# Altium schematic sheet STM32L452RC.SchDoc
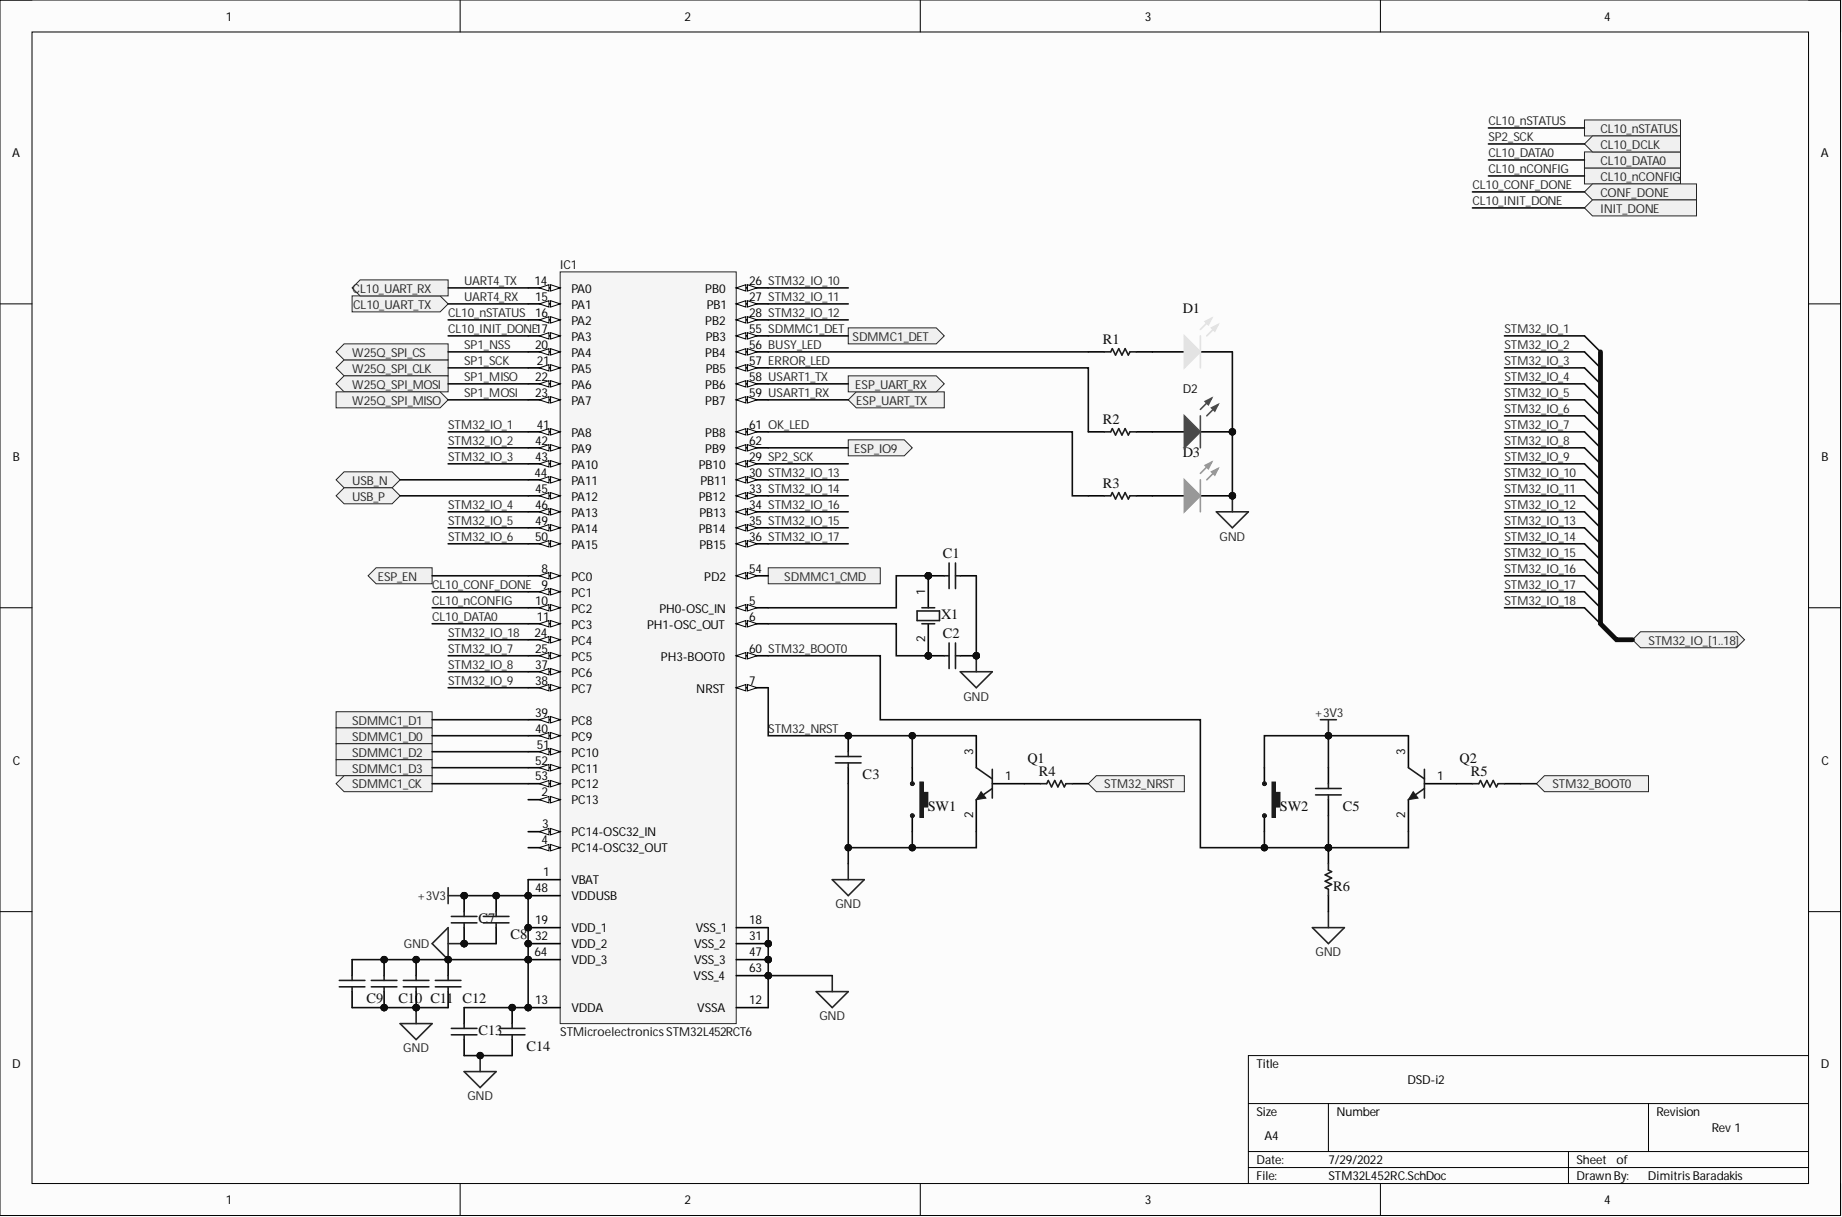
[diagram p0: STM32L452RC.SchDoc]

## Parts
C1  Murata GRM0335C1E6R0BA01D  [CAPC0603X33X15NL03T05]  (value not drawn)
C2  Murata GRM0335C1E6R0BA01D  [CAPC0603X33X15NL03T05]  (value not drawn)
C3  Taiyo Yuden LMK063BJ104KP-F  [PCB-0002-00713-1]  (value not drawn)
C5  Taiyo Yuden LMK063BJ104KP-F  [PCB-0002-00713-1]  (value not drawn)
C7  Samsung CL03A105MO3NRNH  [Samsung CL03A105MO3NRNH-Footprint-1]  (value not drawn)
C8  Taiyo Yuden LMK063BJ104KP-F  [PCB-0002-00713-1]  (value not drawn)
C9  Samsung CL05A475MP5NRNC  [PCB-ly623x0ckhe065kxsmmc-1]  (value not drawn)
C10  Taiyo Yuden LMK063BJ104KP-F  [PCB-0002-00713-1]  (value not drawn)
C11  Taiyo Yuden LMK063BJ104KP-F  [PCB-0002-00713-1]  (value not drawn)
C12  Taiyo Yuden LMK063BJ104KP-F  [PCB-0002-00713-1]  (value not drawn)
C13  Samsung CL03A105MO3NRNH  [Samsung CL03A105MO3NRNH-Footprint-1]  (value not drawn)
C14  Taiyo Yuden LMK063BJ104KP-F  [PCB-0002-00713-1]  (value not drawn)
D1  Würth Elektronik 150060YS75000  [PCB-rxfjlzgnb1funqct5a9u-1]  (value not drawn)
D2  Würth Elektronik 150060SS75000  [PCB-5fu3j7c0qqekthrt71i6-1]  (value not drawn)
D3  Würth Elektronik 150060VS75000  [PCB-6c9d90wl8nak4m67x5qd-1]  (value not drawn)
IC1  STMicroelectronics STM32L452RCT6  Manufacturer Part Number: STM32L452RCT6  [STMicroelectronics STM32L452RCT6-Footprint-1]
Q1  BC848BLT3G  [ONSC-SOT-23-3-318-08_V]  (value not drawn)
Q2  BC848BLT3G  [ONSC-SOT-23-3-318-08_V]  (value not drawn)
R1  Panasonic ERJ-1GNF1620C  [Panasonic ERJ-1GNF1620C-Footprint-1]  (value not drawn)
R2  Panasonic ERJ-1GNF1620C  [Panasonic ERJ-1GNF1620C-Footprint-1]  (value not drawn)
R3  Panasonic ERJ-1GNF1620C  [Panasonic ERJ-1GNF1620C-Footprint-1]  (value not drawn)
R4  Bourns CR0201AJW-103GLF  [Bourns CR0201AJW-103GLF-Footprint-1]  (value not drawn)
R5  Bourns CR0201AJW-103GLF  [Bourns CR0201AJW-103GLF-Footprint-1]  (value not drawn)
R6  Bourns CR0201AJW-103GLF  [Bourns CR0201AJW-103GLF-Footprint-1]  (value not drawn)
SW1  Panasonic EVP-BB2A9B000  [PCB-nuaqfyekbkeoejgoofz7-1]  (value not drawn)
SW2  Panasonic EVP-BB2A9B000  [PCB-nuaqfyekbkeoejgoofz7-1]  (value not drawn)
X1  NDK NX3225GD-8MHZ-STD-CRA-3  [XTAL_2SM_3R2X2R5_A]  (value not drawn)

## Ports
CL10_DATA0, CL10_DCLK, CL10_nCONFIG, CL10_nSTATUS, CL10_UART_RX, CL10_UART_TX, CONF_DONE, ESP_EN, ESP_IO9, ESP_UART_RX, ESP_UART_TX, INIT_DONE, SDMMC1_CK, SDMMC1_CMD, SDMMC1_D0, SDMMC1_D1, SDMMC1_D2, SDMMC1_D3, SDMMC1_DET, STM32_BOOT0, STM32_IO_1, STM32_IO_2, STM32_IO_3, STM32_IO_4, STM32_IO_5, STM32_IO_6, STM32_IO_7, STM32_IO_8, STM32_IO_9, STM32_IO_10, STM32_IO_11, STM32_IO_12, STM32_IO_13, STM32_IO_14, STM32_IO_15, STM32_IO_16, STM32_IO_17, STM32_IO_18, STM32_IO_[1..18], STM32_NRST, USB_N, USB_P, W25Q_SPI_CLK, W25Q_SPI_CS, W25Q_SPI_MISO, W25Q_SPI_MOSI

## Nets
+3V3: C5.2 C7.2 C8.2 C9.2 C10.2 C11.2 C12.2 C13.2 C14.2 IC1.1(VBAT) IC1.13(VDDA) IC1.19(VDD_1) IC1.32(VDD_2) IC1.48(VDDUSB) IC1.64(VDD_3) Q2.3 SW2.1
GND: C1.2 C2.2 C3.1 C7.1 C8.1 C9.1 C10.1 C11.1 C12.1 C13.1 C14.1 D1.2 D2.2 D3.2 IC1.12(VSSA) IC1.18(VSS_1) IC1.31(VSS_2) IC1.47(VSS_3) IC1.63(VSS_4) Q1.2 R6.1 SW1.2
BUSY_LED: IC1.56(PB4) R1.1
CL10_CONF_DONE: IC1.9(PC1) ; port CONF_DONE
CL10_DATA0: IC1.11(PC3) ; port CL10_DATA0
CL10_INIT_DONE: IC1.17(PA3) ; port INIT_DONE
CL10_nCONFIG: IC1.10(PC2) ; port CL10_nCONFIG
CL10_nSTATUS: IC1.16(PA2) ; port CL10_nSTATUS
ERROR_LED: IC1.57(PB5) R2.1
ESP_EN: IC1.8(PC0) ; port ESP_EN
ESP_IO9: IC1.62(PB9) ; port ESP_IO9
OK_LED: IC1.61(PB8) R3.1
SDMMC1_CK: IC1.53(PC12) ; port SDMMC1_CK
SDMMC1_CMD: IC1.54(PD2) ; port SDMMC1_CMD
SDMMC1_D0: IC1.40(PC9) ; port SDMMC1_D0
SDMMC1_D1: IC1.39(PC8) ; port SDMMC1_D1
SDMMC1_D2: IC1.51(PC10) ; port SDMMC1_D2
SDMMC1_D3: IC1.52(PC11) ; port SDMMC1_D3
SDMMC1_DET: IC1.55(PB3) ; port SDMMC1_DET
SP1_MISO: IC1.22(PA6) ; port W25Q_SPI_MOSI
SP1_MOSI: IC1.23(PA7) ; port W25Q_SPI_MISO
SP1_NSS: IC1.20(PA4) ; port W25Q_SPI_CS
SP1_SCK: IC1.21(PA5) ; port W25Q_SPI_CLK
SP2_SCK: IC1.29(PB10) ; port CL10_DCLK
STM32_BOOT0: C5.1 IC1.60(PH3-BOOT0) Q2.2 R6.2 SW2.2
STM32_IO_1: IC1.41(PA8) ; port STM32_IO_[1..18]  [also labelled ]
STM32_IO_2: IC1.42(PA9) ; port STM32_IO_[1..18]  [also labelled ]
STM32_IO_3: IC1.43(PA10) ; port STM32_IO_[1..18]  [also labelled ]
STM32_IO_4: IC1.46(PA13) ; port STM32_IO_[1..18]  [also labelled ]
STM32_IO_5: IC1.49(PA14) ; port STM32_IO_[1..18]  [also labelled ]
STM32_IO_6: IC1.50(PA15) ; port STM32_IO_[1..18]  [also labelled ]
STM32_IO_7: IC1.25(PC5) ; port STM32_IO_[1..18]  [also labelled ]
STM32_IO_8: IC1.37(PC6) ; port STM32_IO_[1..18]  [also labelled ]
STM32_IO_9: IC1.38(PC7) ; port STM32_IO_[1..18]  [also labelled ]
STM32_IO_10: IC1.26(PB0) ; port STM32_IO_[1..18]  [also labelled ]
STM32_IO_11: IC1.27(PB1) ; port STM32_IO_[1..18]  [also labelled ]
STM32_IO_12: IC1.28(PB2) ; port STM32_IO_[1..18]  [also labelled ]
STM32_IO_13: IC1.30(PB11) ; port STM32_IO_[1..18]  [also labelled ]
STM32_IO_14: IC1.33(PB12) ; port STM32_IO_[1..18]  [also labelled ]
STM32_IO_15: IC1.35(PB14) ; port STM32_IO_[1..18]  [also labelled ]
STM32_IO_16: IC1.34(PB13) ; port STM32_IO_[1..18]  [also labelled ]
STM32_IO_17: IC1.36(PB15) ; port STM32_IO_[1..18]  [also labelled ]
STM32_IO_18: IC1.24(PC4) ; port STM32_IO_[1..18]  [also labelled ]
STM32_NRST: C3.2 IC1.7(NRST) Q1.3 SW1.1
UART4_RX: IC1.15(PA1) ; port CL10_UART_TX
UART4_TX: IC1.14(PA0) ; port CL10_UART_RX
USART1_RX: IC1.59(PB7) ; port ESP_UART_TX
USART1_TX: IC1.58(PB6) ; port ESP_UART_RX
USB_N: IC1.44(PA11) ; port USB_N
USB_P: IC1.45(PA12) ; port USB_P
NetC1_1: C1.1 IC1.5(PH0-OSC_IN) X1.1
NetC2_1: C2.1 IC1.6(PH1-OSC_OUT) X1.2
NetD1_1: D1.1 R1.2
NetD2_1: D2.1 R2.2
NetD3_1: D3.1 R3.2
NetQ1_1: Q1.1 R4.1
NetQ2_1: Q2.1 R5.1
NetR4_2: R4.2 ; port STM32_NRST
NetR5_2: R5.2 ; port STM32_BOOT0

Not connected: IC1 2(PC13) 3(PC14-OSC32_IN) 4(PC14-OSC32_OUT)

## Library data of the parts
C1 C2 = CMP-3568632-2: Multilayer Ceramic Capacitors MLCC - SMD/SMT 0201 6.0pF 25volts C0G +/-0.1pF; Capacitance: 6e-12 F; Case/Package (SI): 0603; Dielectric Characteristic: C0G/NP0; Voltage Rating (DC): 25.0 V; Component Kind: Standard; Library Name: My Personal Space; Lifecycle Status: Active; Manufacturer Part Number: GRM0335C1E6R0BA01D; Octopart Url: https://octopart.com/grm0335c1e6r0ba01d-murata-24611420; OctopartID: cfb407c9608e1073; RoHS: Compliant; Size-Length: 0.0006 m; Size-Thickness: 0.0003 m; Size-Width: 0.0003 m
C3 C5 C8 C10-C12 C14 = CMP-e92820824df736ef-2: 0201 0.1 uF 10 V ±10% Tolerance X5R SMT Multilayer Ceramic Capacitor; Capacitance: 100 nF; Lead-Free Status: Lead Free; Voltage Rating (DC): 10.0 V; Capacitance Tolerance: ±10%; Case/Package: 0201; Component Kind: Standard; Dielectric Characteristic: X5R; Halogen Free Status: Halogen Free; Library Name: My Personal Space; Lifecycle Status: Active; Manufacturer Part Number: LMK063BJ104KP-F; Mounting Style: Surface Mount; Number of Pins: 2; Octopart URL: https://octopart.com/lmk063bj104kp-f-taiyo+yuden-10518969; OctopartID: e92820824df736ef; Operating Temperature: -55.0 °C to 85.0 °C; Packaging: Cut Tape (CT); RoHS: Compliant
C7 C13 = CMP-0a3ac1e7a1fcd5fd-1: CL Series 0201 1 uF 16 V ±20% Tolerance X5R Multilayer Ceramic Chip Capacitor; Lead-Free Status: Lead Free; Case/Package: 0201; Component Kind: Standard; Library Name: My Personal Space; Manufacturer Part Number: CL03A105MO3NRNH; Mounting Style: Surface Mount; Octopart Url: https://octopart.com/cl03a105mo3nrnh-samsung-47681184; OctopartID: 0a3ac1e7a1fcd5fd; Packaging: Tape & Reel (TR); RoHS: Compliant
C9 = CMP-45408d1ae5db9a87-4: Cap Ceramic 4.7uF 10V X5R 20% SMD 0402 85°C Paper T/R; Lead-Free Status: Lead Free; Component Kind: Standard; Library Name: My Personal Space; Manufacturer Part Number: CL05A475MP5NRNC; Octopart URL: https://octopart.com/cl05a475mp5nrnc-samsung-22054397; OctopartID: 45408d1ae5db9a87; RoHS: Compliant
D1 = CMP-12518863-10: Led, Yellow, 590 Nm, 2 V, 30 Ma, 120 Mcd Rohs Compliant: Yes; Forward Voltage: 2.00 V; Luminous Intensity: 120 mcd; Viewing Angle: 140 °; Case/Package: 0603; Color: Yellow; Component Kind: Standard; Library Name: My Personal Space; Manufacturer Part Number: 150060YS75000; Mounting Style: Surface Mount; Octopart URL: https://octopart.com/150060ys75000-w%C3%BCrth+elektronik-29687085; OctopartID: fe0f36c1264421c8; Packaging: Tape & Reel (TR); REACH SVHC Compliance: No SVHC; RoHS: Compliant
D2 = CMP-12518860-6: In a Pack of 100, 2.4 V Red LED 1608 (0603) SMD, Wurth Elektronik WL-SMCW 150060SS75000; Forward Voltage: 1.90 V; Luminous Intensity: 60.0 mcd; Viewing Angle: 140 °; Case/Package: 0603; Color: Red; Component Kind: Standard; Library Name: My Personal Space; Manufacturer Part Number: 150060SS75000; Mounting Style: Surface Mount; Octopart URL: https://octopart.com/150060ss75000-w%C3%BCrth+elektronik-29717777; OctopartID: 11d1d91e41a6ce16; Packaging: Reel; REACH SVHC Compliance: No SVHC; RoHS: Compliant
D3 = CMP-12518862-5: Led, Green, 570 Nm, 2 V, 30 Ma, 40 Mcd Rohs Compliant: Yes; Forward Voltage: 2.00 V; Luminous Intensity: 40.0 mcd; Viewing Angle: 140 °; Case/Package: 0603; Color: Green; Component Kind: Standard; Library Name: My Personal Space; Manufacturer Part Number: 150060VS75000; Mounting Style: Surface Mount; Octopart URL: https://octopart.com/150060vs75000-w%C3%BCrth+elektronik-29717778; OctopartID: 8ccb4404dcbf530c; Packaging: Cut Tape (CT); REACH SVHC Compliance: No SVHC; RoHS: Compliant
IC1 = CMP-dd4710ab05420280-1: MCU 32-Bit STM32L452xx ARM Cortex M4 RISC 256KB Flash 1.71V to 3.6V 64-Pin LQFP Tray; Clock Speed: 80.0 MHz; Number of Bits: 32; RAM Memory Size: 163840 B; Component Kind: Standard; Library Name: My Personal Space; Lifecycle Status: Not Listed by Manufacturer; Octopart Url: https://octopart.com/stm32l452rct6-stmicroelectronics-82247011; OctopartID: dd4710ab05420280; RoHS: Compliant
Q1 Q2 = CMP-860696-3: General Purpose Transistor, NPN Silicon, 3-Pin SOT-23, Pb-Free, Tape and Reel; Current Rating: 0.1 A; Lead-Free Status: Lead Free; Voltage Rating (DC): 30.0 V; Case/Package: SOT-23; Component Kind: Standard; Library Name: My Personal Space; Lifecycle Status: Active; Manufacturer Part Number: BC848BLT3G; Mounting Style: Surface Mount; Number of Pins: 3; Octopart Url: https://octopart.com/bc848blt3g-on+semiconductor-835077; OctopartID: 31b1f8b7aad2ee76; Packaging: Tape; Polarity: NPN; RoHS: Compliant
R1-R3 = CMP-3673637-4: Thick Film Resistors 0201 162ohm 1% Halogen Free; Power Rating: 0.05 W; Resistance: 162.0 Ω; Case/Package: 0201; Component Kind: Standard; Library Name: My Personal Space; Lifecycle Status: Not Listed by Manufacturer; Manufacturer Part Number: ERJ-1GNF1620C; Octopart Url: https://octopart.com/erj-1gnf1620c-panasonic-44402935; OctopartID: 2ca1e70e8130f366; Resistance Tolerance: ±1%; RoHS: Compliant
R4-R6 = CMP-0c15c370377fd3d2-2: Thick Film Chip Resistor; 0201 10k 5% 50mW TC200; Component Kind: Standard; Library Name: My Personal Space; Manufacturer Part Number: CR0201AJW-103GLF; Octopart Url: https://octopart.com/cr0201ajw-103glf-bourns-107945484; OctopartID: 0c15c370377fd3d2
SW1 SW2 = CMP-57ca9abfc056880d-3: Switch Tactile N.O. SPST Round Button Gull Wing 0.02A 15VDC 500000Cycles 1.6N SMD T/R; Lead-Free Status: Lead Free; Component Kind: Standard; Library Name: My Personal Space; Lifecycle Status: Not Listed by Manufacturer; Manufacturer Part Number: EVP-BB2A9B000; Octopart URL: https://octopart.com/evp-bb2a9b000-panasonic-75477754; OctopartID: 57ca9abfc056880d; RoHS: Compliant
X1 = CMP-e3332b6ee2093cf4-4: Crystal 8.0000mhz 8pf Smd; Component Kind: Standard; Library Name: My Personal Space; Manufacturer Part Number: NX3225GD-8MHZ-STD-CRA-3; Octopart Url: http://octopart.com/nx3225gd-8mhz-std-cra-3-ndk-22488156; OctopartID: e3332b6ee2093cf4; Seller Landing  Url: https://octopart-clicks.com/click/track?c=1&country=US&ak=8157f166&sig=080ac70&sid=459&ppid=22488156&vpid=48214626&ct=offers
